# Altium schematic sheet Э3("All Documents",Physical)
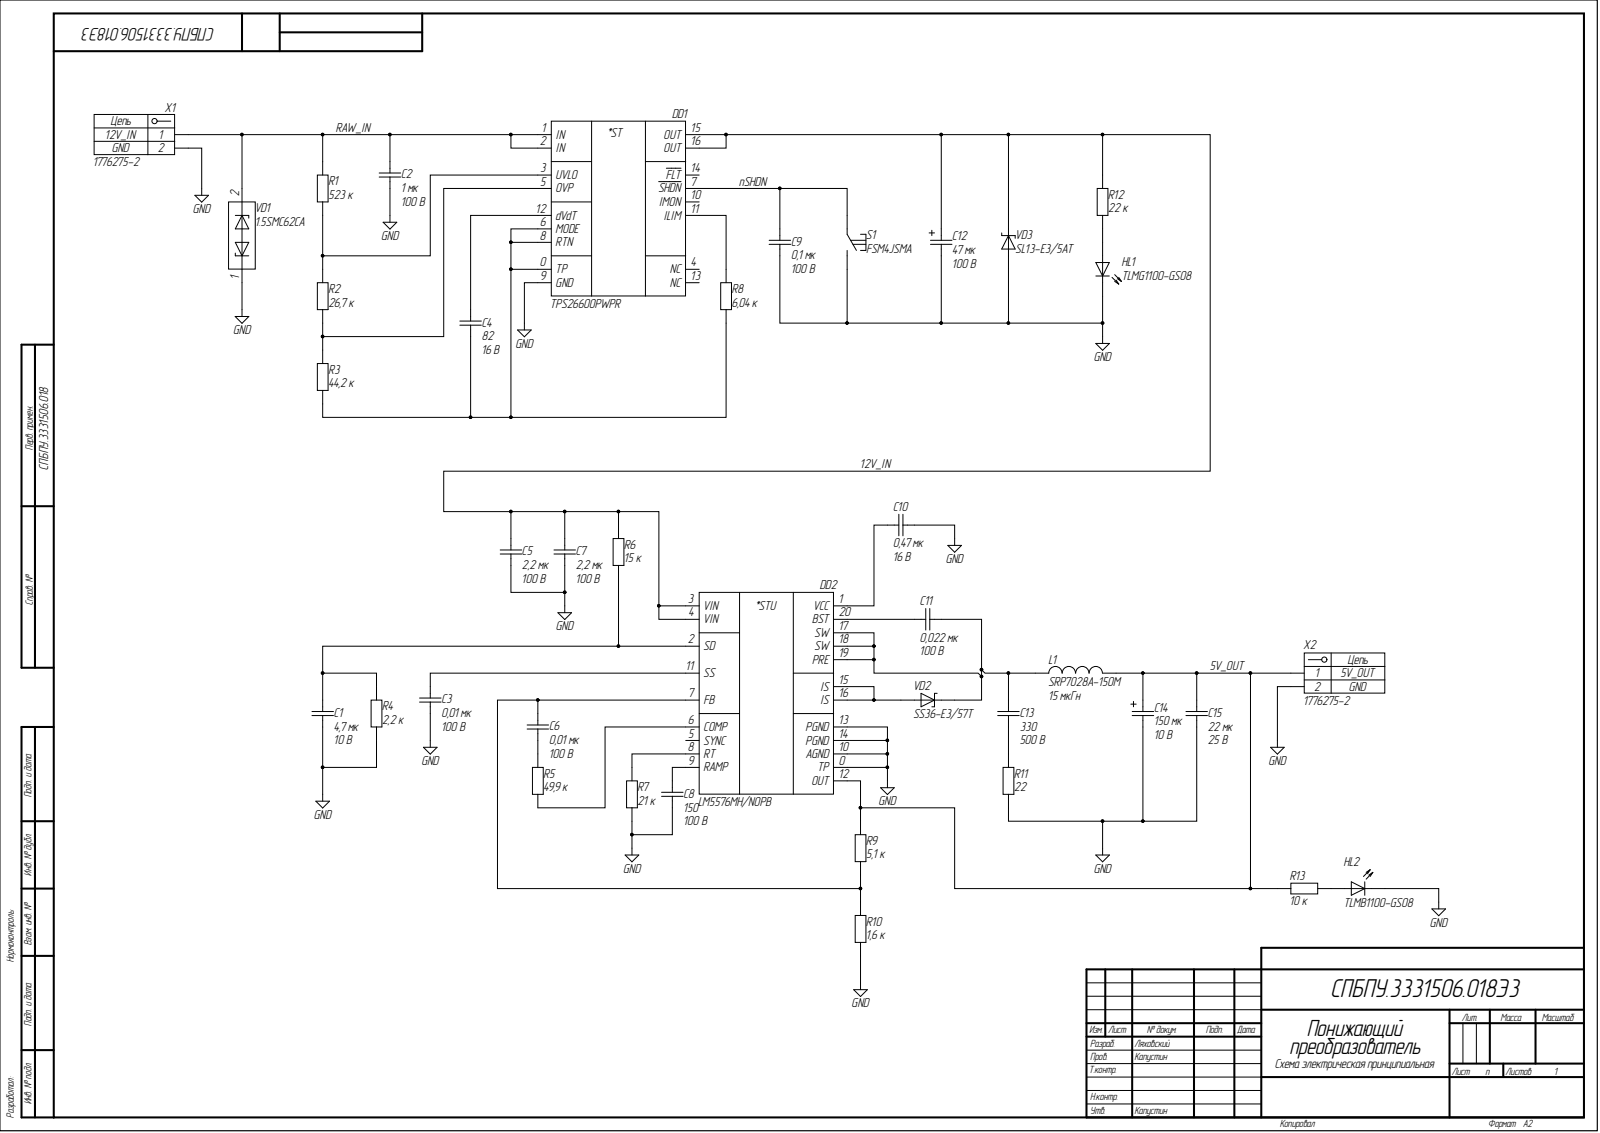
[diagram p0: Э3("All Documents",Physical)]

## Parts
C1  4,7 мк  Номинальное напряжение: 10 В  [C0603]
C2  1 мк  Номинальное напряжение: 100 В  [C1206]
C3  0,01 мк  Номинальное напряжение: 100 В  [C0603]
C4  82  Номинальное напряжение: 16 В  [C0603]
C5  2,2 мк  [C1206]
C6  0,01 мк  [C0603]
C7  2,2 мк  Номинальное напряжение: 100 В  [C1206]
C8  150  Номинальное напряжение: 100 В  [C0805]
C9  0,1 мк  Номинальное напряжение: 100 В  [C0603]
C10  0,47 мк  Номинальное напряжение: 16 В  [C0603]
C11  0,022 мк  Номинальное напряжение: 100 В  [C1210]
C12  47 мк  Номинальное напряжение: 100 В  [CAPAE-1000X1000X1200]
C13  330  Номинальное напряжение: 500 В  [C1210]
C14  150 мк  Номинальное напряжение: 10 В  [CAPAE-660X660X580]
C15  22 мк  Номинальное напряжение: 25 В  [C1210]
DD1  TPS26600PWPR  [HTSSOP-16]
DD2  LM5576MH/NOPB  [HTSSOP-20]
HL1  TLMG1100-GS08  [HL0603]
HL2  TLMB1100-GS08  [HL0603]
L1  SRP7028A-150M  Номинал: 15 мкГн  [SRP-2-730X660X280]
R1  523 к  [R0603]
R2  26,7 к  [R0603]
R3  44,2 к  [R0603]
R4  2,2 к  [R0603]
R5  49,9 к  [R0603]
R6  15 к  [R0603]
R7  21 к  [R0603]
R8  6,04 к  [R0603]
R9  5,1 к  [R0603]
R10  1,6 к  [R0603]
R11  22  [R0603]
R12  22 к  [R0603]
R13  10 к  [R0603]
S1  FSM4JSMA  [FSM4JSMA]
VD1  1.5SMC62CA  [DO-214AB]
VD2  SS36-E3/57T  [DO-214AB]
VD3  SL13-E3/5AT  [DO-214AC]
X1  1776275-2  Contact_2: GND  Contact_1: 12V_IN  [1776275-2]
X2  1776275-2  Contact_2: GND  Contact_1: 5V_OUT  [1776275-2]

## Nets
GND: C1.2 C2.2 C3.2 C5.2 C7.2 C8.2 C9.2 C10.2 C12.2 C14.2 C15.2 DD1.9(GND) DD2.0(TP) DD2.10(AGND) DD2.13(PGND) DD2.14(PGND) HL1.1 HL2.1 R4.1 R7.1 R10.1 R11.1 S1.2 VD1.1 VD3.2 X1.2 X2.2
5V_OUT: C14.1 C15.1 DD2.12(OUT) L1.2 R9.2 R13.1 X2.1
12V_IN: C5.1 C7.1 C12.1 DD1.15(OUT) DD1.16(OUT) DD2.3(VIN) DD2.4(VIN) R6.2 R12.2 VD3.1
nSHDN: C9.1 DD1.7(SHDN) S1.1
RAW_IN: C2.1 DD1.1(IN) DD1.2(IN) R1.2 VD1.2 X1.1
NetC1_1: C1.1 DD2.2(SD) R4.2 R6.1
NetC3_1: C3.1 DD2.11(SS)
NetC4_1: C4.1 DD1.12(dVdT)
NetC4_2: C4.2 DD1.0(TP) DD1.6(MODE) DD1.8(RTN) R3.1 R8.1
NetC6_1: C6.1 DD2.7(FB) R9.1 R10.2
NetC6_2: C6.2 R5.2
NetC8_1: C8.1 DD2.9(RAMP)
NetC10_1: C10.1 DD2.1(VCC)
NetC11_1: C11.1 DD2.20(BST)
NetC11_2: C11.2 C13.1 DD2.17(SW) DD2.18(SW) DD2.19(PRE) L1.1 VD2.1
NetC13_2: C13.2 R11.2
NetDD1_3: DD1.3(UVLO) R1.1 R2.2
NetDD1_4: DD1.4(NC)
NetDD1_5: DD1.5(OVP) R2.1 R3.2
NetDD1_10: DD1.10(IMON)
NetDD1_11: DD1.11(ILIM) R8.2
NetDD1_13: DD1.13(NC)
NetDD1_14: DD1.14(FLT)
NetDD2_5: DD2.5(SYNC)
NetDD2_6: DD2.6(COMP) R5.1
NetDD2_8: DD2.8(RT) R7.2
NetDD2_15: DD2.15(IS) DD2.16(IS) VD2.2
NetHL1_2: HL1.2 R12.1
NetHL2_2: HL2.2 R13.2

## Library data of the parts
C1 = c0082: =Описание; Фамилия: Паньков; Температурный коэффициент емкости: X7R; Рабочие температуры: от –55 °С до +125 °С; Производитель: YAGEO Corp.; Отклонение: ±10 %; Описание: Конденсатор чип 0603 4,7 мкФ 10 В ±10 %; Наименование: CC0603KRX7R6BB475; Корпус: 0603; Database Table Name: Конденсаторы$; Номинал: 4,7 мк; Напряжение: 10 В; ID: c0082; HelpURL: https://www.yageo.com/upload/media/product/productsearch/datasheet/mlcc/UPY-GPHC_X7R_6.3V-to-50V_20.pdf; Description: Конденсатор чип 0603 4,7 мкФ 10 В ±10 %; Component Kind: Standard; Library Name: Database_Students.DbLib
C2 = c0066: =Описание; Фамилия: Ларионов; Температурный коэффициент емкости: X7R; Рабочие температуры: от –55 °С до +125 °С; Производитель: YAGEO Corp.; Отклонение: ±10 %; Описание: Конденсатор чип 1206 1 мкФ 100 В ±10 %; Наименование: CC1206KKX7R0BB105; Корпус: 1206; Database Table Name: Конденсаторы$; Номинал: 1 мк; Напряжение: 100 В; ID: c0066; HelpURL: https://www.yageo.com/upload/media/product/productsearch/datasheet/mlcc/UPY-NP0X7R_MV_100-to-630V_22.pdf; Description: Конденсатор чип 1206 1 мкФ 100 В ±10 %; Component Kind: Standard; Library Name: Database_Students.DbLib
C3 = c0021: =Описание; Фамилия: Ларионов; Температурный коэффициент емкости: X7R; Рабочие температуры: от –55 °С до +125 °С; Производитель: YAGEO Corp.; Отклонение: ±5 %; Описание: Конденсатор чип 0603 10 нФ 100 В ±5 %; Наименование: CC0603JRX7R0BB103; Корпус: 0603; Database Table Name: Конденсаторы$; Номинал: 0,01 мк; Напряжение: 100 В; ID: c0021; HelpURL: https://www.yageo.com/upload/media/product/productsearch/datasheet/mlcc/UPY-NP0X7R_MV_100-to-630V_22.pdf; Description: Конденсатор чип 0603 10 нФ 100 В ±5 %; Component Kind: Standard; Library Name: Database_Students.DbLib
C4 = c0011: =Описание; Фамилия: Ларионов; Температурный коэффициент емкости: C0G; Рабочие температуры: от –55 °С до +125 °С; Производитель: YAGEO Corp.; Отклонение: ±5 %; Описание: Конденсатор чип 0603 82 пФ 16 В ±5 %; Наименование: CC0603JRNPO7BN820; Корпус: 0603; Database Table Name: Конденсаторы$; Номинал: 82; Напряжение: 16 В; ID: c0011; HelpURL: https://www.yageo.com/upload/media/product/productsearch/datasheet/mlcc/UPY-GP_NP0_16V-to-50V_18.pdf; Description: Конденсатор чип 0603 82 пФ 16 В ±5 %; Component Kind: Standard; Library Name: Database_Students.DbLib
C5 = c0076: =Описание; Фамилия: Ларионов; Температурный коэффициент емкости: X7R; Рабочие температуры: от –55 °С до +125 °С; Производитель: YAGEO Corp.; Отклонение: ±10 %; Описание: Конденсатор чип 1206 2,2 мкФ 100 В ±10 %; Номинальное напряжение: 100 В; Наименование: CC1206KKX7R0BB225; Корпус: 1206; Database Table Name: Конденсаторы$; Номинал: 2,2 мк; Напряжение: 100 В; ID: c0076; HelpURL: https://www.yageo.com/upload/media/product/productsearch/datasheet/mlcc/UPY-NP0X7R_MV_100-to-630V_22.pdf; Description: Конденсатор чип 1206 2,2 мкФ 100 В ±10 %; Component Kind: Standard; Library Name: Database_Students.DbLib
C6 = c0021: =Описание; Фамилия: Ларионов; Температурный коэффициент емкости: X7R; Рабочие температуры: от –55 °С до +125 °С; Производитель: YAGEO Corp.; Отклонение: ±5 %; Описание: Конденсатор чип 0603 10 нФ 100 В ±5 %; Номинальное напряжение: 100 В; Наименование: CC0603JRX7R0BB103; Корпус: 0603; Database Table Name: Конденсаторы$; Номинал: 0,01 мк; Напряжение: 100 В; ID: c0021; HelpURL: https://www.yageo.com/upload/media/product/productsearch/datasheet/mlcc/UPY-NP0X7R_MV_100-to-630V_22.pdf; Description: Конденсатор чип 0603 10 нФ 100 В ±5 %; Component Kind: Standard; Library Name: Database_Students.DbLib
C7 = c0076: =Описание; Фамилия: Ларионов; Температурный коэффициент емкости: X7R; Рабочие температуры: от –55 °С до +125 °С; Производитель: YAGEO Corp.; Отклонение: ±10 %; Описание: Конденсатор чип 1206 2,2 мкФ 100 В ±10 %; Наименование: CC1206KKX7R0BB225; Корпус: 1206; Database Table Name: Конденсаторы$; Номинал: 2,2 мк; Напряжение: 100 В; ID: c0076; HelpURL: https://www.yageo.com/upload/media/product/productsearch/datasheet/mlcc/UPY-NP0X7R_MV_100-to-630V_22.pdf; Description: Конденсатор чип 1206 2,2 мкФ 100 В ±10 %; Component Kind: Standard; Library Name: Database_Students.DbLib
C8 = c0121: =Описание; Фамилия: Ляховский; Температурный коэффициент емкости: C0G; Рабочие температуры: от –55 °С до +125 °С; Производитель: KEMET Corporation; Отклонение: ±2 %; Описание: Конденсатор чип 0805 150 пФ 100 В ±2 %; Наименование: C0805C151G1GACTU; Корпус: 0805; Database Table Name: Конденсаторы$; Номинал: 150; Напряжение: 100 В; ID: c0121; HelpURL: https://www.kemet.com/en/us/capacitors/ceramic/product/C0805C151G1GACTU.html; Description: Конденсатор чип 0805 150 пФ 100 В ±2 %; Component Kind: Standard; Library Name: Database_Students.DbLib
C9 = c0036: =Описание; Фамилия: Ларионов; Температурный коэффициент емкости: X7R; Рабочие температуры: от –55 °С до +125 °С; Производитель: YAGEO Corp.; Отклонение: ±10 %; Описание: Конденсатор чип 0603 100 нФ 100 В ±10 %; Наименование: CC0603KRX7R0BB104; Корпус: 0603; Database Table Name: Конденсаторы$; Номинал: 0,1 мк; Напряжение: 100 В; ID: c0036; HelpURL: https://www.yageo.com/upload/media/product/productsearch/datasheet/mlcc/UPY-NP0X7R_MV_100-to-630V_22.pdf; Description: Конденсатор чип 0603 100 нФ 100 В ±10 %; Component Kind: Standard; Library Name: Database_Students.DbLib
C10 = c0054: =Описание; Фамилия: Паньков; Температурный коэффициент емкости: X7R; Рабочие температуры: от –55 °С до +125 °С; Производитель: YAGEO Corp.; Отклонение: ±10 %; Описание: Конденсатор чип 0603 470 нФ 16 В ±10 %; Наименование: CC0603KRX7R7BB474; Корпус: 0603; Database Table Name: Конденсаторы$; Номинал: 0,47 мк; Напряжение: 16 В; ID: c0054; HelpURL: https://www.yageo.com/upload/media/product/products/datasheet/mlcc/UPY-GPHC_X7R_6.3V-to-50V_20.pdf; Description: Конденсатор чип 0603 470 нФ 16 В ±10 %; Component Kind: Standard; Library Name: Database_Students.DbLib
C11 = c0119: =Описание; Фамилия: Ляховский; Температурный коэффициент емкости: X7R; Рабочие температуры: от –55 °С до +125 °С; Производитель: YAGEO Corp.; Отклонение: ±10 %; Описание: Конденсатор чип 1210 0,022 мкФ 100 В ±10 %; Наименование: CC1210KKX7R0BB223; Корпус: 1210; Database Table Name: Конденсаторы$; Номинал: 0,022 мк; Напряжение: 100 В; ID: c0119; HelpURL: https://www.yageo.com/upload/media/product/productsearch/datasheet/mlcc/UPY-NP0X7R_MV_100-to-630V_22.pdf; Description: Конденсатор чип 1210 0,022 мкФ 100 В ±10 %; Component Kind: Standard; Library Name: Database_Students.DbLib
C12 = c0118: =Описание; Фамилия: Ларионов; Рабочие температуры: от –55 °С до +125 °С; Производитель: Vishay Intertechnology, Inc.; Отклонение: ±20 %; Описание: Конденсатор электролитический 47 мкФ 100 В ±20 %; Наименование: MAL214699904E3; Корпус: CAPAE (10 x 10 x 12); Database Table Name: Конденсаторы$; Температурный коэффициент емкости: -; Номинал: 47 мк; Напряжение: 100 В; ID: c0118; HelpURL: https://www.vishay.com/capacitors/list/product-28403/; Description: Конденсатор электролитический 47 мкФ 100 В ±20 %; Component Kind: Standard; Library Name: Database_Students.DbLib
C13 = c0012: =Описание; Фамилия: Ляховский; Температурный коэффициент емкости: C0G; Рабочие температуры: от –55 °С до +125 °С; Производитель: YAGEO Corp.; Отклонение: ±5 %; Описание: Конденсатор чип 1210 330 пФ 500 В ±10 %; Наименование: CC1210JKNPOBBN331; Корпус: 1210; Database Table Name: Конденсаторы$; Номинал: 330; Напряжение: 500 В; ID: c0012; HelpURL: https://www.yageo.com/upload/media/product/productsearch/datasheet/mlcc/UPY-NP0X7R_MV_100-to-630V_22.pdf; Description: Конденсатор чип 1210 330 пФ 500 В ±10 %; Component Kind: Standard; Library Name: Database_Students.DbLib
C14 = c0120: =Описание; Фамилия: Водорезов; Рабочие температуры: от –55 °С до +105 °С; Производитель: Panasonic Corporation; Отклонение: ±20 %; Описание: Конденсатор электролитический 150 мкФ 10 В ±20 %; Наименование: EEEFK1A151AL; Корпус: CAPAE (6,6 x 6,6 x 5,8); Database Table Name: Конденсаторы$; Температурный коэффициент емкости: -; Номинал: 150 мк; Напряжение: 10 В; ID: c0120; HelpURL: https://industrial.panasonic.com/ww/products/capacitors/aluminum-capacitors/aluminum-cap-smd/models/EEEFK1A151AL; Description: Конденсатор электролитический 150 мкФ 10 В ±20 %; Component Kind: Standard; Library Name: Database_Students.DbLib
C15 = c0113: =Описание; Фамилия: Ляпцев; Температурный коэффициент емкости: X5R; Рабочие температуры: от –55 °С до +85 °С; Производитель: Murata Manufacturing Co., Ltd.; Отклонение: ±10 %; Описание: Конденсатор чип 1210 22 мкФ 25 В ±10 %; Наименование: GRM32ER61E226KE15L; Корпус: 1210; Database Table Name: Конденсаторы$; Номинал: 22 мк; Напряжение: 25 В; ID: c0113; HelpURL: https://www.murata.com/en-us/products/productdetail?partno=GRM32ER61E226KE15%23; Description: Конденсатор чип 1210 22 мкФ 25 В ±10 %; Component Kind: Standard; Library Name: Database_Students.DbLib
DD1 = dd0001: =Описание; Фамилия: Ларионов; Ток: от 0,1 А до 2,23 А; Рабочие температуры: от -40 °С до +125 °С; Производитель: Texas Instruments; Описание: Защитная микросхема eFuse 60 В и 2 А; Напряжение: от 4,2 В до 60 В; Максимальное напряжение: 62 В; Database Table Name: Защитные микросхемы$; Наименование: TPS26600PWPR; Корпус: HTSSOP-16; ID: dd0001; HelpURL: https://www.ti.com/product/TPS2660; Description: Защитная микросхема eFuse 60 В и 2 А; Component Kind: Standard; Library Name: Database_Students.DbLib
DD2 = dd0016: =Описание; Частота переключения: от 50 кГц до 500 кГц; Фамилия: Ляховский; Рабочие температуры: от –40 °С до +125 °С; Производитель: Texas Instruments; Описание: Понижающий преобразователь напряжения 75 В и 3 А; Коммутируемый ток: до 3 А; Выходное напряжение: от 1,23 В до 70 В; Входное напряжение: от 6 В до 75 В; Database Table Name: Преобразователи напряжения$; Наименование: LM5576MH/NOPB; Корпус: HTSSOP-20; ID: dd0016; HelpURL: https://www.ti.com/product/LM5576; Description: Понижающий преобразователь напряжения 75 В и 3 А; Component Kind: Standard; Library Name: Database_Students.DbLib
HL1 = hl0005: =Описание; Цвет: Green; Фамилия: Ларионов; Рассеиваемая мощность: 90 мВт; Рабочие температуры: от –40 °С до +100 °С; Производитель: Vishay Intertechnology, Inc.; Описание: Светодиод чип 0603 зеленый; Номинальный прямой ток: 30 мА; Номинальное падение напряжения: 2,1 В; Номинальная сила света: 35 мкд; Номинальная длина волны: 570 нм; Корпус: 0603; Database Table Name: Светодиоды$; Наименование: TLMG1100-GS08; ID: hl0005; HelpURL: https://www.vishay.com/leds/list/product-83173/; Description: Светодиод чип 0603 зеленый; Component Kind: Standard; Library Name: Database_Students.DbLib
HL2 = hl0006: =Описание; Цвет: Blue; Фамилия: Ларионов; Рассеиваемая мощность: 90 мВт; Рабочие температуры: от –40 °С до +100 °С; Производитель: Vishay Intertechnology, Inc.; Примечание: Not for new design; Описание: Светодиод чип 0603 синий; Номинальный прямой ток: 30 мА; Номинальное падение напряжения: 3,9 В; Номинальная сила света: 5 мкд; Номинальная длина волны: 466 нм; Корпус: 0603; Database Table Name: Светодиоды$; Наименование: TLMB1100-GS08; ID: hl0006; HelpURL: https://www.vishay.com/leds/list/product-83173/; Description: Светодиод чип 0603 синий; Component Kind: Standard; Library Name: Database_Students.DbLib
L1 = l0009: =Описание; Фамилия: Ляховский; Ток насыщения: 4 А; Резонансная частота: 12 МГц; Рабочие температуры: от –55 °С до +150 °С; Рабочее напряжение: не указано; Производитель: Bourns, Inc.; Отклонение: ±20 %; Описание: Катушка индуктивности 15 мкГн ±20 %; Номинальный ток (при нагреве на 20 °С/40 °С): 3 А; Номинальное активное сопротивление: 107 мОм; Максимальное активное сопротивление: 123 мОм; Корпус: 7,3 x 6,6 x 2,8; Database Table Name: Катушки индуктивности$; Наименование: SRP7028A-150M; Индуктивность: 15 мкГн; ID: l0009; HelpURL: https://www.bourns.com/products/magnetic-products/power-inductors-aec-q200-compliant/product/SRP7028A; Description: Катушка индуктивности 15 мкГн ±20 %; Component Kind: Standard; Library Name: Database_Students.DbLib
R1 = r0119: =Описание; Фамилия: Жернаков; Рабочие температуры: от –55 °С до +155 °С; Производитель: YAGEO Corp.; Отклонение: ±1 %; Описание: Резистор чип 0603 523 кОм ±1 % 0,1 Вт; Номинальный ток: 1 А; Номинальное напряжение: 75 В; Наименование: RC0603FR-07523KL; Корпус: 0603; Database Table Name: Резисторы$; Номинал: 523 к; ID: r0119; HelpURL: https://www.yageo.com/upload/media/product/productsearch/datasheet/rchip/PYu-RC_Group_51_RoHS_L_11.pdf; Description: Резистор чип 0603 523 кОм ±1 % 0,1 Вт; Component Kind: Standard; Library Name: Database_Students.DbLib
R2 = r0073: =Описание; Фамилия: Ларионов; Рабочие температуры: от –55 °С до +155 °С; Производитель: YAGEO Corp.; Отклонение: ±1 %; Описание: Резистор чип 0603 26,7 кОм ±1 % 0,1 Вт; Номинальный ток: 1 А; Номинальное напряжение: 75 В; Наименование: RC0603FR-0726K7L; Корпус: 0603; Database Table Name: Резисторы$; Номинал: 26,7 к; ID: r0073; HelpURL: https://www.yageo.com/upload/media/product/productsearch/datasheet/rchip/PYu-RC_Group_51_RoHS_L_11.pdf; Description: Резистор чип 0603 26,7 кОм ±1 % 0,1 Вт; Component Kind: Standard; Library Name: Database_Students.DbLib
R3 = r0082: =Описание; Фамилия: Ларионов; Рабочие температуры: от –55 °С до +155 °С; Производитель: YAGEO Corp.; Отклонение: ±1 %; Описание: Резистор чип 0603 44,2 кОм ±1 % 0,1 Вт; Номинальный ток: 1 А; Номинальное напряжение: 75 В; Наименование: RC0603FR-0744K2L; Корпус: 0603; Database Table Name: Резисторы$; Номинал: 44,2 к; ID: r0082; HelpURL: https://www.yageo.com/upload/media/product/productsearch/datasheet/rchip/PYu-RC_Group_51_RoHS_L_11.pdf; Description: Резистор чип 0603 44,2 кОм ±1 % 0,1 Вт; Component Kind: Standard; Library Name: Database_Students.DbLib
R4 = r0040: =Описание; Фамилия: Паньков; Рабочие температуры: от –55 °С до +155 °С; Производитель: YAGEO Corp.; Примечание: Ряд E24; Отклонение: ±1 %; Описание: Резистор чип 0603 2,2 кОм ±1 % 0,1 Вт; Номинальный ток: 1 А; Номинальное напряжение: 75 В; Наименование: RC0603FR-072K2L; Корпус: 0603; Database Table Name: Резисторы$; Номинал: 2,2 к; ID: r0040; HelpURL: https://www.yageo.com/upload/media/product/productsearch/datasheet/rchip/PYu-RC_Group_51_RoHS_L_11.pdf; Description: Резистор чип 0603 2,2 кОм ±1 % 0,1 Вт; Component Kind: Standard; Library Name: Database_Students.DbLib
R5 = r0129: =Описание; Фамилия: Ляховский; Рабочие температуры: от –55 °С до +155 °С; Производитель: YAGEO Corp.; Отклонение: ±1 %; Описание: Резистор чип 0603 49,9 кОм ±1 % 0,1 Вт; Номинальный ток: 1 А; Номинальное напряжение: 75 В; Наименование: RC0603FR-0749K9L; Корпус: 0603; Database Table Name: Резисторы$; Номинал: 49,9 к; ID: r0129; HelpURL: https://www.yageo.com/upload/media/product/productsearch/datasheet/rchip/PYu-RC_Group_51_RoHS_L_11.pdf; Description: Резистор чип 0603 49,9 кОм ±1 % 0,1 Вт; Component Kind: Standard; Library Name: Database_Students.DbLib
R6 = r0066: =Описание; Фамилия: Паньков; Рабочие температуры: от –55 °С до +155 °С; Производитель: YAGEO Corp.; Примечание: Ряд E24; Отклонение: ±1 %; Описание: Резистор чип 0603 15 кОм ±1 % 0,1 Вт; Номинальный ток: 1 А; Номинальное напряжение: 75 В; Наименование: RC0603FR-0715KL; Корпус: 0603; Database Table Name: Резисторы$; Номинал: 15 к; ID: r0066; HelpURL: https://www.yageo.com/upload/media/product/productsearch/datasheet/rchip/PYu-RC_Group_51_RoHS_L_11.pdf; Description: Резистор чип 0603 15 кОм ±1 % 0,1 Вт; Component Kind: Standard; Library Name: Database_Students.DbLib
R7 = r0130: =Описание; Фамилия: Ляховский; Рабочие температуры: от –55 °С до +155 °С; Производитель: YAGEO Corp.; Примечание: Ряд E24; Отклонение: ±1 %; Описание: Резистор чип 0603 21 кОм ±1 % 0,1 Вт; Номинальный ток: 1 А; Номинальное напряжение: 75 В; Наименование: RC0603FR-0721KL; Корпус: 0603; Database Table Name: Резисторы$; Номинал: 21 к; ID: r0130; HelpURL: https://www.yageo.com/upload/media/product/productsearch/datasheet/rchip/PYu-RC_Group_51_RoHS_L_11.pdf; Description: Резистор чип 0603 21 кОм ±1 % 0,1 Вт; Component Kind: Standard; Library Name: Database_Students.DbLib
R8 = r0053: =Описание; Фамилия: Ларионов; Рабочие температуры: от –55 °С до +155 °С; Производитель: YAGEO Corp.; Отклонение: ±1 %; Описание: Резистор чип 0603 6,04 кОм ±1 % 0,1 Вт; Номинальный ток: 1 А; Номинальное напряжение: 75 В; Наименование: RC0603FR-076K04L; Корпус: 0603; Database Table Name: Резисторы$; Номинал: 6,04 к; ID: r0053; HelpURL: https://www.yageo.com/upload/media/product/productsearch/datasheet/rchip/PYu-RC_Group_51_RoHS_L_11.pdf; Description: Резистор чип 0603 6,04 кОм ±1 % 0,1 Вт; Component Kind: Standard; Library Name: Database_Students.DbLib
R9 = r0051: =Описание; Фамилия: Жернаков; Рабочие температуры: от –55 °С до +155 °С; Производитель: YAGEO Corp.; Примечание: Ряд E24; Отклонение: ±1 %; Описание: Резистор чип 0603 5,1 кОм ±1 % 0,1 Вт; Номинальный ток: 1 А; Номинальное напряжение: 75 В; Наименование: RC0603FR-075K1L; Корпус: 0603; Database Table Name: Резисторы$; Номинал: 5,1 к; ID: r0051; HelpURL: https://www.yageo.com/upload/media/product/productsearch/datasheet/rchip/PYu-RC_Group_51_RoHS_L_11.pdf; Description: Резистор чип 0603 5,1 кОм ±1 % 0,1 Вт; Component Kind: Standard; Library Name: Database_Students.DbLib
R10 = r0037: =Описание; Фамилия: Паньков; Рабочие температуры: от –55 °С до +155 °С; Производитель: YAGEO Corp.; Примечание: Ряд E24; Отклонение: ±1 %; Описание: Резистор чип 0603 1,6 кОм ±1 % 0,1 Вт; Номинальный ток: 1 А; Номинальное напряжение: 75 В; Наименование: RC0603FR-071K6L; Корпус: 0603; Database Table Name: Резисторы$; Номинал: 1,6 к; ID: r0037; HelpURL: https://www.yageo.com/upload/media/product/productsearch/datasheet/rchip/PYu-RC_Group_51_RoHS_L_11.pdf; Description: Резистор чип 0603 1,6 кОм ±1 % 0,1 Вт; Component Kind: Standard; Library Name: Database_Students.DbLib
R11 = r0004: =Описание; Фамилия: Ларионов; Рабочие температуры: от –55 °С до +155 °С; Производитель: YAGEO Corp.; Примечание: Ряд E24; Отклонение: ±1 %; Описание: Резистор чип 0603 22 Ом ±1 % 0,1 Вт; Номинальный ток: 1 А; Номинальное напряжение: 75 В; Наименование: RC0603FR-0722RL; Корпус: 0603; Database Table Name: Резисторы$; Номинал: 22; ID: r0004; HelpURL: https://www.yageo.com/upload/media/product/productsearch/datasheet/rchip/PYu-RC_Group_51_RoHS_L_11.pdf; Description: Резистор чип 0603 22 Ом ±1 % 0,1 Вт; Component Kind: Standard; Library Name: Database_Students.DbLib
R12 = r0071: =Описание; Фамилия: Паньков; Рабочие температуры: от –55 °С до +155 °С; Производитель: YAGEO Corp.; Примечание: Ряд E24; Отклонение: ±1 %; Описание: Резистор чип 0603 22 кОм ±1 % 0,1 Вт; Номинальный ток: 1 А; Номинальное напряжение: 75 В; Наименование: RC0603FR-0722KL; Корпус: 0603; Database Table Name: Резисторы$; Номинал: 22 к; ID: r0071; HelpURL: https://www.yageo.com/upload/media/product/productsearch/datasheet/rchip/PYu-RC_Group_51_RoHS_L_11.pdf; Description: Резистор чип 0603 22 кОм ±1 % 0,1 Вт; Component Kind: Standard; Library Name: Database_Students.DbLib
R13 = r0060: =Описание; Фамилия: Самарин; Рабочие температуры: от –55 °С до +155 °С; Производитель: YAGEO Corp.; Примечание: Ряд E24; Отклонение: ±1 %; Описание: Резистор чип 0603 10 кОм ±1 % 0,1 Вт; Номинальный ток: 1 А; Номинальное напряжение: 75 В; Наименование: RC0603FR-0710KL; Корпус: 0603; Database Table Name: Резисторы$; Номинал: 10 к; ID: r0060; HelpURL: https://www.yageo.com/upload/media/product/productsearch/datasheet/rchip/PYu-RC_Group_51_RoHS_L_11.pdf; Description: Резистор чип 0603 10 кОм ±1 % 0,1 Вт; Component Kind: Standard; Library Name: Database_Students.DbLib
S1 = s0001: =Описание; Фамилия: Паньков; Ток нагрузки: 50 мА @ 24 В; Сопротивление контакта: 100 мОм; Рабочие температуры: от –35 °С до +85 °С; Промывка: Разрешена; Производитель: TE Connectivity; Описание: Тактовая кнопка поверхностного монтажа; Корпус: 6,00x6,00x4,98; Количество контактов: 4; Database Table Name: Переключатели$; Наименование: FSM4JSMA; ID: s0001; HelpURL: https://www.te.com/usa-en/product-4-1437565-1.html; Description: Тактовая кнопка поверхностного монтажа; Component Kind: Standard; Library Name: Database_Students.DbLib
VD1 = vd0006: =Описание; Фамилия: Ларионов; Производитель: Littelfuse, Inc.; Описание: Двунаправленная диодная сборка для подавления помех, 53 В; Database Table Name: Диодные сборки$; Наименование: 1.5SMC62CA; Корпус: DO-214AB; ID: vd0006; HelpURL: https://www.littelfuse.com/products/tvs-diodes/surface-mount/1_5smc.aspx; Description: Двунаправленная диодная сборка для подавления помех, 53 В; Component Kind: Standard; Library Name: Database_Students.DbLib
VD2 = vd0004: =Описание; Фамилия: Жернаков; Рабочие температуры: от –55 °С до +150 °С; Производитель: Vishay Intertechnology, Inc.; Пиковое прямое напряжение: 0,75 В; Описание: Диод Шоттки 60 В 3 А; Макс допустимый средний прямой ток: 3 А; Импульсное обратное напряжение: 60 В; Database Table Name: Диоды$; Наименование: SS36-E3/57T; Корпус: DO-214AB; ID: vd0004; HelpURL: https://www.vishay.com/product?docid=88751; Description: Диод Шоттки 60 В 3 А; Component Kind: Standard; Library Name: Database_Students.DbLib
VD3 = vd0002: =Описание; Фамилия: Ларионов; Рабочие температуры: от –55 °С до +125 °С; Производитель: Vishay Intertechnology, Inc.; Пиковое прямое напряжение: 0,445 В; Описание: Диод Шоттки 30 В 1,5 А; Макс допустимый средний прямой ток: 1,5 А; Импульсное обратное напряжение: 30 В; Database Table Name: Диоды$; Наименование: SL13-E3/5AT; Корпус: DO-214AC; ID: vd0002; HelpURL: https://www.vishay.com/product?docid=88740; Description: Диод Шоттки 30 В 1,5 А; Component Kind: Standard; Library Name: Database_Students.DbLib
X1 X2 = x0005: =Описание; Фамилия: Паньков; Производитель: TE Connectivity; Описание: Клеммная колодка 2 pin 3,5 мм; Database Table Name: Разъемы$; Наименование: 1776275-2; ID: x0005; HelpURL: https://www.te.com/usa-en/product-1776275-2.html; Description: Клеммная колодка 2 pin 3,5 мм; Component Kind: Standard; Library Name: Database_Students.DbLib
